# Altium schematic sheet Main.SchDoc
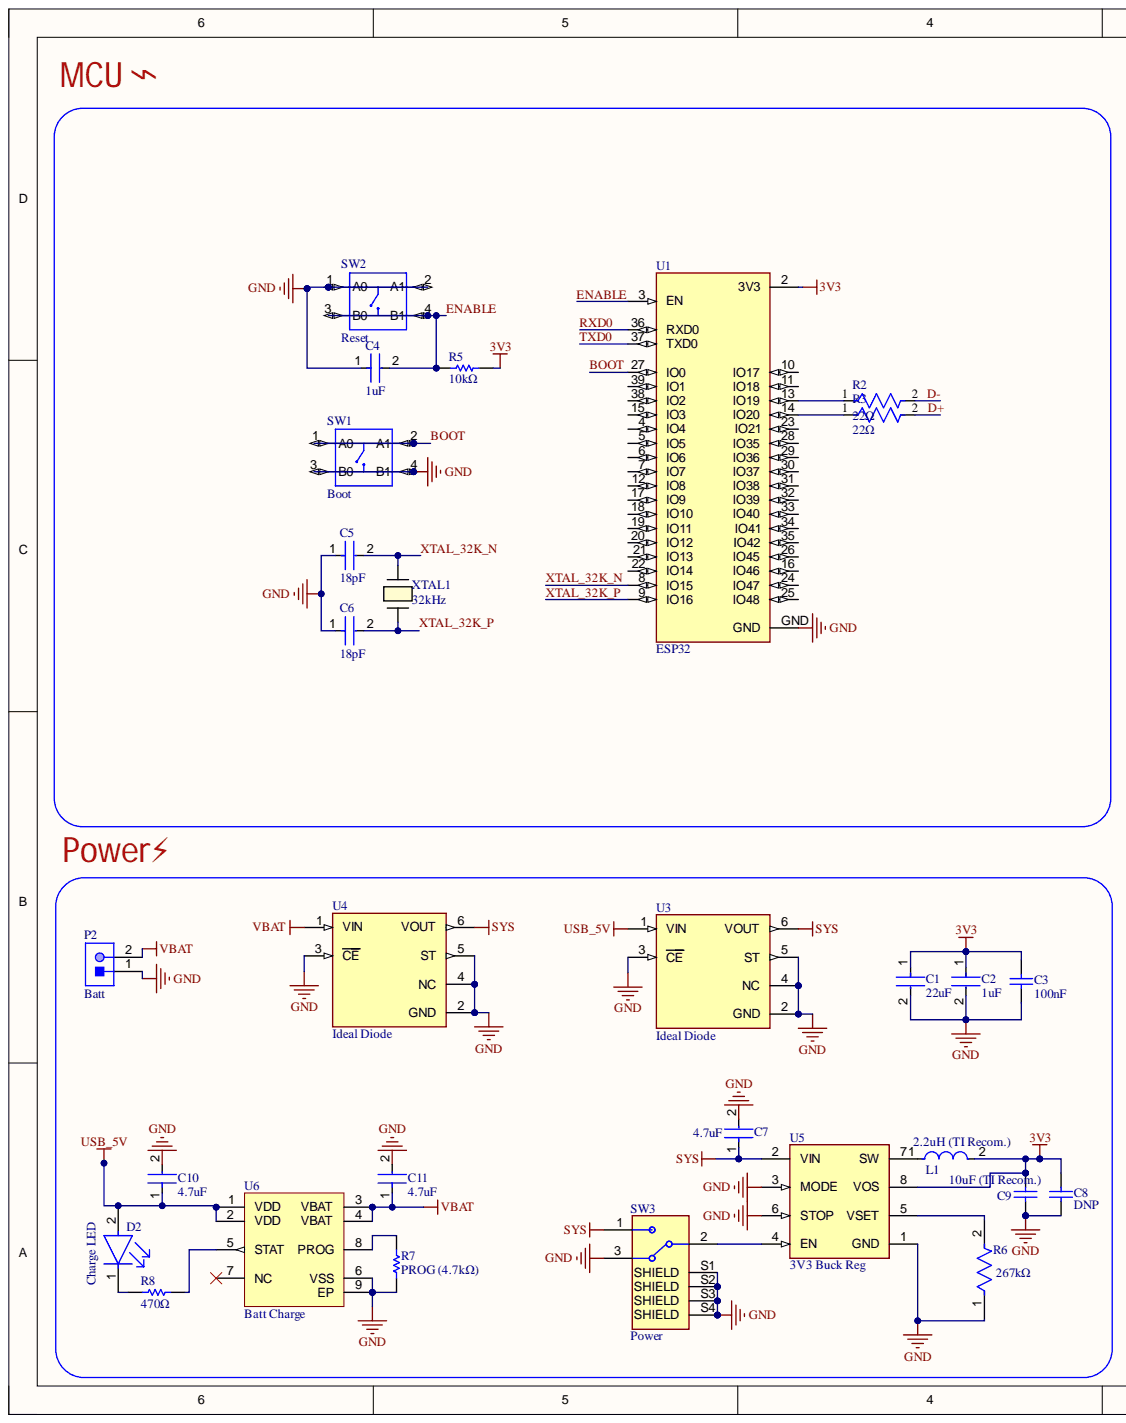
[diagram p0: Main.SchDoc, left]
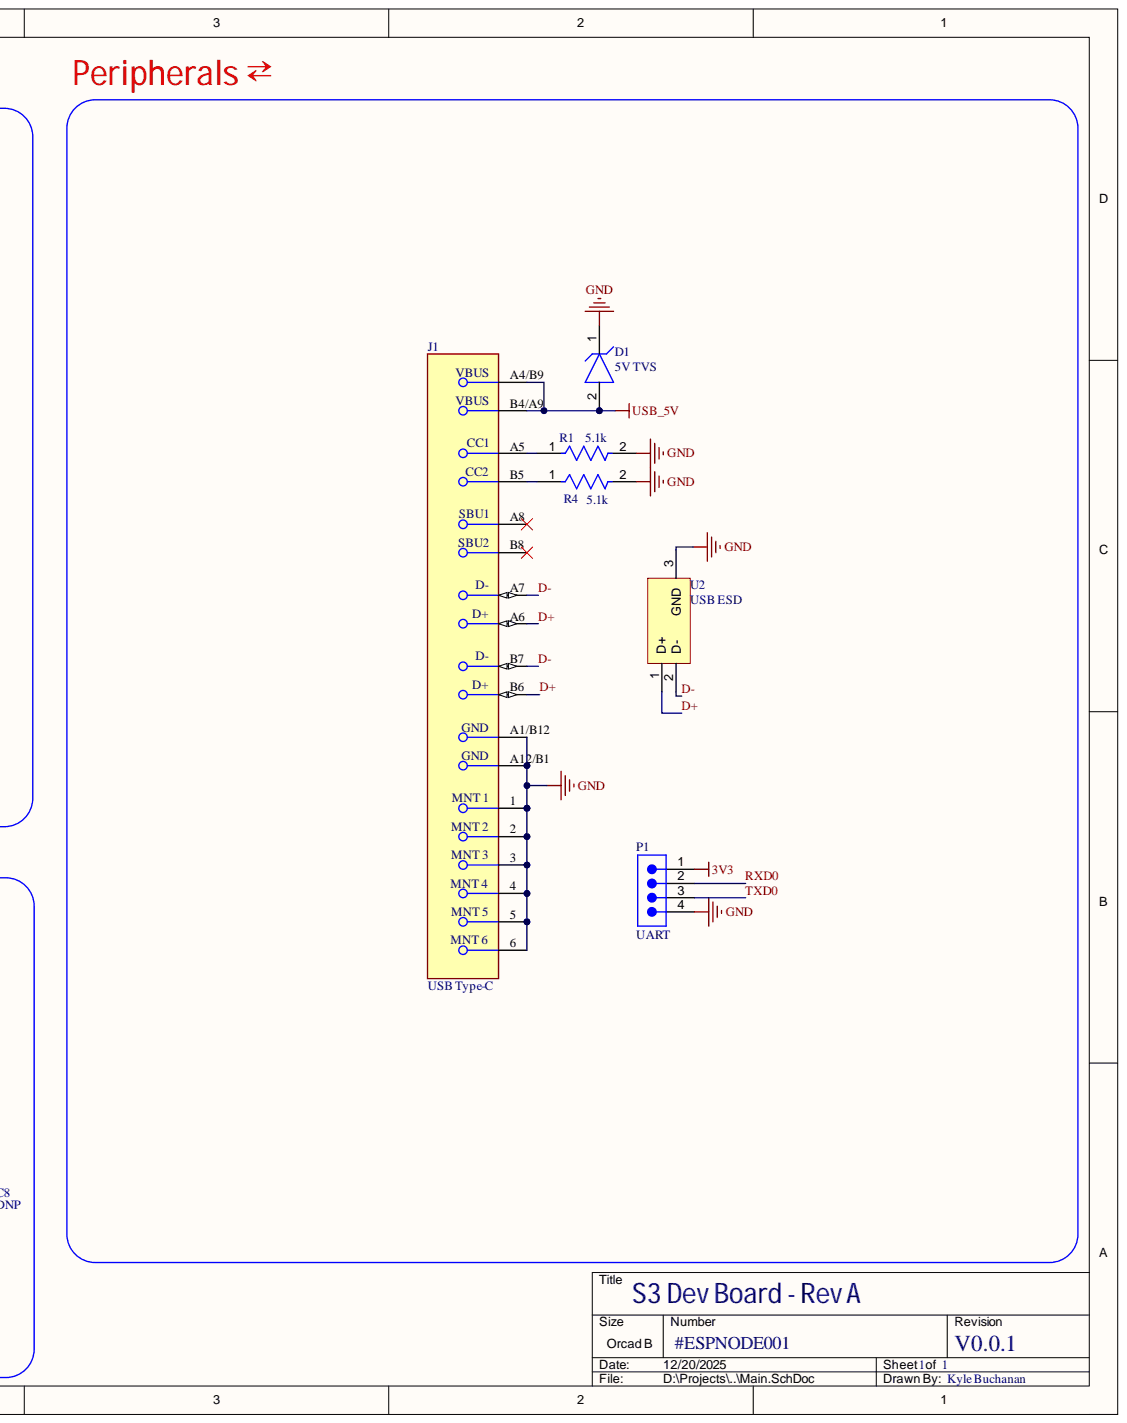
[diagram p1: Main.SchDoc, right]

## Parts
C1  22uF  [FP-CL21-IPC_A]
C2  1uF  [FP-CL10-IPC_A]
C3  100nF  [CAPC1050X55X23ML05T13]
C4  1uF  [FP-CL10-IPC_A]
C5  18pF  [FP-CL10-IPC_A]
C6  18pF  [FP-CL10-IPC_A]
C7  4.7uF  [FP-CL10-IPC_C]
C8  DNP  [CAPC1005X55X25NL05T10]  (no BOM)
C9  10uF (TI Recom.)  [CAPC1005X55X25NL05T10]
C10  4.7uF  [FP-CL10-IPC_C]
C11  4.7uF  [FP-CL10-IPC_C]
D1  5V TVS  Reverse Standoff Voltage: 5V  [FP-SMB-MFG]
D2  Charge LED  [FP-LTST-C170GKT-MFG]
J1  USB Type-C  [FP-DX07S016JA1R1500-MFG]
L1  2.2uH (TI Recom.)  [FP-DFE201612C-MFG]
P1  UART  [SMTC-TSW-104-08-X-S]  (no BOM)
P2  Batt  [JST-S2B-PH-K-S_V]
R1  5.1k  [FP-CRCW0603-e3-IPC_A]
R2  22Ω  [FP-RC0402-0_4-MFG]
R3  22Ω  [FP-RC0402-0_4-MFG]
R4  5.1k  [FP-CRCW0603-e3-IPC_A]
R5  10kΩ  [RESC1005X40X25LL05T10]
R6  267kΩ  [FP-RT0603-MFG]
R7  PROG (4.7kΩ)  [YAGO-RC0805_M]
R8  470Ω  [RESC1005X40X25ML05T10]
SW1  Boot  [SW4-SMD-5.2X5.2X1.5MM]
SW2  Reset  [SW4-SMD-5.2X5.2X1.5MM]
SW3  Power  [SW_PCM12SMTR]
U1  ESP32  [XCVR_ESP32-S3-WROOM-1-N16R2]
U2  USB ESD  [DRT0003A]
U3  Ideal Diode  [SOT65P210X110-6N]
U4  Ideal Diode  [SOT65P210X110-6N]
U5  3V3 Buck Reg  Switching Topology: Buck  [FP-DLC0008B-MFG]
U6  Batt Charge  [DFN-MC8]
XTAL1  32kHz  [XTAL_FC-135R_EPS]

## Nets
3V3: C1.1 C2.1 C3.2 C8.2 C9.2 L1.2 P1.1 R5.2 U1.2(3V3) U5.8(VOS)
GND: C1.2 C2.2 C3.1 C4.1 C5.1 C6.1 C7.2 C8.1 C9.1 C10.2 C11.2 D1.1 J1.1 J1.2 J1.3 J1.4 J1.5 J1.6 J1.A1/B12 J1.A12/B1 P1.4 P2.1 R1.2 R4.2 R6.1 R7.1 SW1.3(B0) SW1.4(B1) SW2.1(A0) SW2.2(A1) SW3.3 SW3.S1(SHIELD) SW3.S2(SHIELD) SW3.S3(SHIELD) SW3.S4(SHIELD) U1.GND U2.3 U3.2(GND) U3.3(CE) U3.4(NC) U3.5(ST) U4.2(GND) U4.3(CE) U4.4(NC) U4.5(ST) U5.1(GND) U5.3(MODE) U5.6(STOP) U6.6(VSS) U6.9(EP)
SYS: C7.1 SW3.1 U3.6(VOUT) U4.6(VOUT) U5.2(VIN)
USB_5V: C10.1 D1.2 D2.2 J1.A4/B9 J1.B4/A9 U3.1(VIN) U6.1(VDD) U6.2(VDD)
VBAT: C11.1 P2.2 U4.1(VIN) U6.3(VBAT) U6.4(VBAT)
BOOT: SW1.1(A0) SW1.2(A1) U1.27(IO0)
D+: J1.A6 J1.B6 R3.2 U2.1
D-: J1.A7 J1.B7 R2.2 U2.2
ENABLE: C4.2 R5.1 SW2.3(B0) SW2.4(B1) U1.3(EN)
RXD0: P1.2 U1.36(RXD0)
TXD0: P1.3 U1.37(TXD0)
XTAL_32K_N: C5.2 U1.8(IO15) XTAL1.2
XTAL_32K_P: C6.2 U1.9(IO16) XTAL1.1
NetD2_1: D2.1 R8.1
NetJ1_A5: J1.A5 R1.1
NetJ1_B5: J1.B5 R4.1
NetL1_1: L1.1 U5.7(SW)
NetR2_1: R2.1 U1.13(IO19)
NetR3_1: R3.1 U1.14(IO20)
NetR6_2: R6.2 U5.5(VSET)
NetR7_2: R7.2 U6.8(PROG)
NetR8_2: R8.2 U6.5(STAT)
NetSW3_2: SW3.2 U5.4(EN)

Not connected: J1 A8 B8; U1 4(IO4) 5(IO5) 6(IO6) 7(IO7) 10(IO17) 11(IO18) 12(IO8) 15(IO3) 16(IO46) 17(IO9) 18(IO10) 19(IO11) 20(IO12) 21(IO13) 22(IO14) 23(IO21) 24(IO47) 25(IO48) 26(IO45) 28(IO35) 29(IO36) 30(IO37) 31(IO38) 32(IO39) 33(IO40) 34(IO41) 35(IO42) 38(IO2) 39(IO1); U6 7(NC)

## Library data of the parts
C1 = CMP-13271-000185-1: CL21 Series 0805 22uF 6.3V ±20% Tolerance X5R Multilayer Ceramic Chip Capacitor; MPN: CL21A226MQQNNNE; Capacitance: 22uF; Case Code (Imperial): 0805; Case Code (Metric): 2012; Case/Package: 0805; Component Kind: Standard; Contact Plating: Tin; Depth: 1.25mm; Dielectric: X5R; Height: 1.25mm; LCSC Part #: C5674; Lead Free: Lead Free; Length: 2mm; Library Name: Altium Content Vault; Max Operating Temperature: 85°C; Min Operating Temperature: -55°C; Mount: Surface Mount; Package Quantity: 2000; Packaging: Tape and Reel; Radiation Hardening: No; Thickness: 0.055inch; Tolerance: 20%; Voltage Rating: 6.3V; Voltage Rating (DC): 6.3V; Width: 0.049inch
C2 C4 = CMP-13271-001457-1: Cap Ceramic 1uF 16V X5R ±10% Pad SMD 0603 +85°C T/R; MPN: CL10A105KO8NNNC; Capacitance: 1uF; Case Code (Imperial): 0603; Case Code (Metric): 1608; Case/Package: 0603; Component Kind: Standard; Contact Plating: Tin; Depth: 0.8mm; Dielectric: X5R; Height: 0.8mm; LCSC Part #: C1592; Lead Free: Lead Free; Length: 1.6mm; Library Name: Altium Content Vault; Material: Ceramic; Max Operating Temperature: 85°C; Min Operating Temperature: -55°C; Mount: Surface Mount; Package Quantity: 1; Packaging: Tape and Reel; Radiation Hardening: No; Thickness: 0.035inch; Tolerance: 10%; Voltage: 16V; Voltage Rating: 16V; Voltage Rating (DC): 16V; Width: 0.031inch
C3 = CMP-2000-05439-1: Chip Capacitor, 100 nF, +/- 10%, 16 V, -55 to 125 degC, 0402 (1005 Metric), RoHS, Tape and Reel; Manufacturer: Samsung Electro-Mechanics; MPN: CL05B104KO5NNNC; Capacitance: 100000pF; Dielectric: X7R; Rated Voltage: 16V; Case Size/Dimension: 0402; Component Kind: Standard; Datasheet URL: https://datasheet.ciiva.com/29198/458718-da-01-en-vs-kondensator-0402-29198423.pdf; Datasheet Version: Nov-10; LCSC Part #: C1525; Library Name: Altium Content Vault; Manufacturer URL: http://www.samsungsem.com/global/index.jsp; Tolerance (%): ±10%
C5 C6 = CMP-2000-06099-2: Cap Ceramic 18pF 50V C0G ±5% Pad SMD 0603 +125°C T/R; MPN: CL10C180JB8NNNC; Temperature Coefficient: 300ppm/°C; Capacitance: 18pF; Case Code (Imperial): 0603; Case Code (Metric): 1608; Case/Package: 0603; Component Kind: Standard; Contact Plating: Tin; Depth: 0.8mm; Dielectric: C0G; Height: 0.8mm; LCSC Part #: C1647; Length: 1.6mm; Library Name: Altium Content Vault; Material: Ceramic; Max Operating Temperature: 125°C; Min Operating Temperature: -55°C; Mount: Surface Mount; Packaging: Tape and Reel; Radiation Hardening: No; Thickness: 0.035inch; Tolerance: 5%; Voltage: 50V; Voltage Rating: 50V; Width: 0.031inch
C7 C10 C11 = CMP-13271-003017-1: Cap Ceramic 4.7uF 10V X5R ±10% Pad SMD 0603 +85°C T/R; MPN: CL10A475KP8NNNC; Capacitance: 4.7uF; Case Code (Imperial): 0603; Case Code (Metric): 1608; Case/Package: 0603; Component Kind: Standard; Contact Plating: Tin; Depth: 0.8mm; Dielectric: X5R; Height: 0.8mm; LCSC Part #: C1705; Length: 1.6mm; Library Name: Altium Content Vault; Max Operating Temperature: 85°C; Min Operating Temperature: -55°C; Mount: Surface Mount; Package Quantity: 1; Packaging: Tape and Reel; Radiation Hardening: No; Thickness: 0.035inch; Tolerance: 10%; Voltage Rating: 10V; Width: 0.031inch
C8 = CMP-2008-04012-1: Manufacturer: Murata; Part Number: GRM155R60G106ME44D; LCSC Part #: DNP; Library Name: Altium Content Vault; MPN: DNP
C9 = CMP-2008-04012-1: Manufacturer: Murata; MPN: GRM155R60G106ME44D; Component Kind: Standard; LCSC Part #: C162167; Library Name: Altium Content Vault; Part Number: GRM155R60G106ME44D
D1 = CMP-12828-000017-1: TVS DIODE 5V 9.2V SMB; Manufacturer: Diodes Inc; Manufacturer Part Number: SMBJ5.0A-13-F; Breakdown Voltage: 6.4V; Direction: Unidirectional; Max Junction Temp: +150°C; Number Of Channels: 1; Peak Pulse Current: 65.2A; Peak Pulse Power: 600W; Standoff Height: 0.05mm; Automotive: No; Category: Diode; Clamping Voltage: 9.2V; Component Kind: Standard; ComponentLink2Description: Datasheet; ComponentLink2URL: https://www.diodes.com/assets/Datasheets/ds19002.pdf; Device Class L1: Circuit Protection; Device Class L2: TVS Diodes; Device Class L3: unset; Digikey Description: TVS DIODE 5V 9.2V SMB; Footprint Url: https://www.diodes.com/assets/Package-Files/SMB.pdf; Height: 2.5mm; LCSC Part #: C110528; Lead Free: Yes; Library Name: Altium Content Vault; Mouser Description: TVS Diodes - Transient Voltage Suppressors 600W 5.0V; MPN: SMBJ5.0A-13-F; Octopart: https://octopart.com/smbj5.0a-13-f-diodes+inc.-350946; Package: SMB; RoHS: TRUE; Temperature: -55°C to +150°C; Temperature Range High: +150°C; Temperature Range Low: -55°C
D2 = CMP-1674-00002-6: LED GREEN CLEAR SMD; MPN: LTST-C170GKT; Dominant Wavelength: 569nm; Forward Current: 30mA; Forward Voltage: 2.1V; Lens Color: Colorless; Lens Style: Diffused; Luminous Intensity: 6mcd; Number of Elements: 1; Power Dissipation: 100mW; Reverse Voltage: 5V; Test Current: 10mA; Viewing Angle: 130°; Wavelength: 565nm; Case Code (Imperial): 0805; Case Code (Metric): 2012; Case/Package: 0805; Color: Green; Component Kind: Standard; Depth: 1.25mm; Height: 1.1mm; Illumination Color: Green; LCSC Part #: C125090; Lead Free: Lead Free; Length: 2mm; Lens Transparency: Clear; Library Name: Altium Content Vault; Max Operating Temperature: 85°C; Min Operating Temperature: -55°C; Mount: Surface Mount; Number of LEDs: 1; Number of Pins: 2; Package Quantity: 1; Packaging: Tape and Reel; Power Rating: 100mW; Radiation Hardening: No; Voltage: 5V; Width: 1.25mm
J1 = CMP-19038-000003-1: CONN RCP USB2.0 TYP C 24P SMD RA; MPN: DX07S016JA1R1500; Component Kind: Standard; LCSC Part #: C3197885; Library Name: Altium Content Vault
L1 = CMP-06042-009962-1: Shielded Wirewound Inductor 2.2uH 20% 1800mA 0.116Ω 0806 (2016); MPN: DFE201612E-2R2M=P2; Component Kind: Standard; LCSC Part #: C337893; Library Name: Altium Content Vault
P1 = CMP-1024-00357-1: 0.025" SQ Post Header, Through-hole, Vertical, -55 to 125 degC, 2.54 mm Pitch, 4-Pin, Male, RoHS; Manufacturer: Samtec; MPN: DNP; PartNumber: TSW-104-08-G-S; Pitch: 2.54 mm; ComponentLink1Description: Manufacturer URL; ComponentLink1URL: http://www.samtec.com; ComponentLink2Description: Datasheet; ComponentLink2URL: http://www.samtec.com/documents/webfiles/pdf/TSW_TH.PDF; ComponentLink3Description: Package Specification; ComponentLink3URL: http://www.samtec.com/documents/webfiles/cpdf/TSW-XXX-XX-XXX-X-XX-XXX-MKT.pdf; DatasheetVersion: F-214; LCSC Part #: DNP; Library Name: Altium Content Vault; Mounting Technology: Through-hole; Orientation: Vertical; PackageDescription: .025[0.64] SQ. Post Terminal Strip Assembly; PackageReference: TSW-104-08-X-S; PackageVersion: DM, 8/1988; RoHS: True; Temperature Range: -55 to 125 degC
P2 = CMP-1755-00004-1: Male Header, Pitch 2 mm, 1 x 2 Position, Height 4.8 mm, Tail Length 3.4 mm, -25 to 85 degC, RoHS, Bulk; MPN: S2B-PH-K-S(LF)(SN); Case\Package: Radial; Tolerance: 20%; Voltage Rating: 50 V; Component Kind: Standard; ComponentLink1Description: Datasheet; ComponentLink1URL: http://www.jst-mfg.com/product/pdf/eng/ePH.pdf; DatasheetVersion: Dec-12; Diameter: 5 mm; Height: 11 mm; LCSC Part #: C173752; Lead Pitch: 2 mm; Length: 11 mm; Library Name: Altium Content Vault; Max Operating Temperature: 85 degC; Min Operating Temperature: -40 degC; Mount: Through Hole; Package Quantity: 200; PackageDescription: Male Header, Pitch 2 mm, 1 x 2 Position, Height 4.8 mm, Tail Length 3.4 mm; PackageReference: S2B-PH-K-S; Packaging: Bulk; Pins: 2; Radiation Hardening: No; REACH SVHC: No SVHC; RoHSCompliant: true; Voltage Rating (DC): 50 V
R1 R4 = CMP-2000-03480-2: RES Thick Film, 5.1kΩ, 5%, 0.1W, 200ppm/°C, 0603; MPN: CRCW06035K10JNEA; Max Power Dissipation: 100mW; Resistance: 5.1kR; Voltage Rating: 75V; Case Code (Imperial): 0603; Case Code (Metric): 1608; Case/Package: 0603; Component Kind: Standard; Composition: Thick Film; Contact Plating: Tin; Depth: 0.85mm; Features: Automotive AEC-Q200; Height: 0.02inch; LCSC Part #: C14677; Lead Free: Lead Free; Length: 1.55mm; Library Name: Altium Content Vault; Max Operating Temperature: 155°C; Military Standard: Not; Min Operating Temperature: -55°C; Mount: Surface Mount; Number of Pins: 2; Number of Terminations: 2; Package Quantity: 1; Packaging: Tape and Reel; Power Rating: 100mW; Radiation Hardening: No; Temperature Coefficient: 200ppm/°C; Termination: SMD/SMT; Tolerance: 5%; Voltage Rating (DC): 75V; Weight: 7.1E-05oz; Width: 0.85mm
R2 R3 = CMP-2002-07520-2: Thick Film Resistors – SMD; YAGEO; RC0402FR-0722RL; 22 Ohm; 0402; 1 %; Power Rating: 63mW; Temperature Coefficient: 100ppm/°C; Tolerance: 1%; Voltage Rating: 50V; Case Code (Imperial): 0402; Case/Package: 0402; Component Kind: Standard; Composition: Thick Film; Depth: 500µm; Height: 400µm; LCSC Part #: C114765; Lead Free: Lead Free; Length: 1mm; Library Name: Altium Content Vault; Max Operating Temperature: 155°C; Military Standard: Not; Min Operating Temperature: -55°C; MPN: RC0402FR-0722RL; Number of Pins: 2; Packaging: Tape & Reel; REACH SVHC: Unknown; Resistance: 22Ω; RoHS: Compliant; Schedule B: 8533210045, 8533210045; Termination: SMD/SMT; Voltage Rating (AC): 50V; Voltage Rating (DC): 50V; Width: 500µm
R5 = CMP-2002-07353-1: Resistor, thick film, SMD 0402 (1005), 10 kΩ, 0.063 W, ±1 %; Manufacturer: Yageo / Phycomp; MPN: RC0402FR-0710KL; Component Kind: Standard; LCSC Part #: C60490; Library Name: Altium Content Vault; Part Number: RC0402FR-0710KL
R6 = CMP-03412-013520-1: RES SMD 267K OHM 0.1% 1/10W 0603; Manufacturer: Yageo; Manufacturer Part Number: RT0603BRE07267KL; Power Rating: 0.1W; Temperature Coefficient: 50 ppm/°C; Tolerance: 0.001; Voltage Rating: 75V; Automotive: No; Category: Res; Component Kind: Standard; ComponentLink2Description: Datasheet; ComponentLink2URL: http://www.yageo.com/documents/recent/PYu-RT_1-to-0.01_RoHS_L_9.pdf; Composition: Thin Film; Device Class L1: Passive Components; Device Class L2: Resistors; Device Class L3: Chip SMD Resistors; Digikey Description: RES SMD 267K OHM 0.1% 1/10W 0603; Footprint Url: http://www.yageo.com/exep/pages/download/literatures/PYu-R_Mount_10.pdf; Height: 0.55mm; Ipc Land Pattern Name: RESC16080X45; LCSC Part #: C861785; Lead Free: Yes; Library Name: Altium Content Vault; MPN: RT0603BRE07267KL; Octopart: https://octopart.com/rt0603bre07267kl-yageo-1458490; Package: RT0603; Resistance: 267KΩ; RoHS: TRUE; Series: RT; Temperature: -55°C to +155°C; Temperature Range High: +155°C; Temperature Range Low: -55°C; Value: 267KΩ
R7 = CMP-1568-00001-1: General Puprose Chip Resistor, 4700 Ohm +/- 1%, 0.125 W, -55 to 155 degC, 2-Pin SMD, RoHS, Tape and Reel; MPN: RC0805FR-074K7L; Power: 0.125 W; Tolerance: +/- 1%; Component Kind: Standard; ComponentLink1Description: Manufacturer URL; ComponentLink1URL: http://www.yageo.com/NewPortal/_en/index.jsp; ComponentLink2Description: Datasheet; ComponentLink2URL: http://www.yageo.com/documents/recent/PYu-RC_Group_51_RoHS_L-3.pdf; DatasheetVersion: Rev. 3; LCSC Part #: C60816; Library Name: Altium Content Vault; Mounting Technology: Surface Mount; PackageDescription: 2-Pin Surface Mount Device, Body 2 x 1.25 mm; Packing: Tape and Reel; PartNumber: RC0805FR-074K7L; Resistance: 4700 Ohm; RoHS: TRUE; Temperature Max: 155 degC; Temperature Min: -55 degC
R8 = CMP-2002-07697-1: Manufacturer: Yageo / Phycomp; MPN: RC0402FR-07470RL; Component Kind: Standard; LCSC Part #: C114877; Library Name: Altium Content Vault; Part Number: RC0402FR-07470RL
SW1 SW2 = SMD-BUTTON(4P-5.2X5.2X1.5MM-SKQGADE010): Switch Tactile N.O. SPST Round Button Gull Wing 0.05A 12VDC 2.55N SMD T/R; MF: ALPS; Check_prices: https://www.snapeda.com/parts/SKQGADE010/ALPS/view-part/?ref=eda; Component Kind: Standard; LCSC Part #: C116647; Library Name: SMD-BUTTON_4P-5.2X5.2X1.5MM-SKQGADE010_.IntLib; MP: SKQGADE010; MPN: SKQGADE010; Package: SMD-4 ALPS; SnapEDA_Link: https://www.snapeda.com/parts/SKQGADE010/ALPS/view-part/?ref=snap
SW3 = PCM12SMTR: Slide Switch SPDT Surface Mount, Right Angle; MF: C&K; Availability: In Stock; Check_prices: https://www.snapeda.com/parts/PCM12SMTR/C%2526K/view-part/?ref=eda; Component Kind: Standard; LCSC Part #: C221841; Library Name: PCM12SMTR.IntLib; MP: PCM12SMTR; MPN: PCM12SMTR; Package: None; Price: None; Purchase-URL: https://pricing.snapeda.com/search/part/PCM12SMTR/?ref=eda; SnapEDA_Link: https://www.snapeda.com/parts/PCM12SMTR/C%2526K/view-part/?ref=snap
U1 = ESP32-S3-WROOM-1-N16R2: Bluetooth, WiFi 802.11b/g/n, Bluetooth v5.0 Transceiver Module 2.4GHz ESP32-S3-WROOM-1-N16R2; MF: Espressif Systems; MP: ESP32S3WROOM1N16R2; Check_prices: https://www.snapeda.com/parts/ESP32S3WROOM1N16R2/Espressif+Systems/view-part/?ref=eda; Component Kind: Standard; LCSC Part #: C2913205; Library Name: ESP32-S3-WROOM-1-N16R2.IntLib; MPN: ESP32-S3-WROOM-1-N16R2; Package: NON STANDARD Espressif Systems; SnapEDA_Link: https://www.snapeda.com/parts/ESP32S3WROOM1N16R2/Espressif+Systems/view-part/?ref=snap
U2 = CMP-0326-00046-3: ESD Solution for Super-Speed (6 Gbps) USB 3.0 Interface, 2 Channels, -40 to +85 degC, 3-pin SOT (DRT), Green (Rohs & No Sb/Br); Manufacturer: Texas Instruments; MPN: TPD2EUSB30DRTR; 1394 / Firewire: TRUE; Breakdown Voltage(Min)(V): 9; Differential Capacitance(pF): 0.01; IEC 61000-4-2 Air-Gap(+/- kV): 8; IO Capacitance(Typ)(pF): 0.7; IO Leakage Current(nA): 10; Component Kind: Standard; ComponentLink1Description: Datasheet; ComponentLink1URL: http://www.ti.com/general/docs/lit/getliterature.tsp?genericPartNumber=TPD2EUSB30&fileType=pdf; ComponentLink2Description: URL Link1; ComponentLink2URL: SamplesURL:http://www.ti.com/product/tpd2eusb30#samples; DatasheetVersion: SLVSAC2C; Eco-Plan: Green (RoHS & no Sb/Br); HDMI: TRUE; IEC 61000-4-2 Contact(+/- kV): 8; LCSC Part #: C97502; Library Name: Altium Content Vault; Mounting Technology: Surface Mount; PackageDescription: 3-Flat Lead Plastic Small Outline, 1 x 1 mm (inc leads), 0.35 mm Pitch; PackageReference: DRT0003A; PackageVersion: revD, Jun-2008; PartNumber: TPD2EUSB30DRTR; Serial ATA / eSATA: TRUE; SubFamily: ESD Solution; USB Full Speed: TRUE; USB High Speed: TRUE; USB Super Speed: TRUE
U3 U4 = LM66100DCKR: 1.5-V to 5.5-V, 1.5-A, 0.5-ÂµA IQ ideal diode with integrated FET; MF: Texas Instruments; Check_prices: https://www.snapeda.com/parts/LM66100DCKR/Texas+Instruments/view-part/?ref=eda; Component Kind: Standard; LCSC Part #: C2869734; Library Name: LM66100DCKR.IntLib; MP: LM66100DCKR; MPN: LM66100DCKR; Package: SOT-SC70-6 Texas Instruments; SnapEDA_Link: https://www.snapeda.com/parts/LM66100DCKR/Texas+Instruments/view-part/?ref=snap
U5 = CMP-04918-000086-1: ULTRA LOW IQ BUCK CONVERTER; Manufacturer: Texas Instruments; Manufacturer Part Number: TPS62840DLCR; Max Duty Cycle: 100%; Max Junction Temp: +125°C; Max Supply Voltage: 6.5V; Min Supply Voltage: 1.8V; Nominal Supply Current: 0.005-3000uA; Number Of Outputs: 1; Output Current: 750mA; Output Type: AdjustableProgrammable; Output Voltage: 1.8-3.3V; Standoff Height: 0mm; Switching Frequency: 1.8MHz; Automotive: No; Category: IC; Component Kind: Standard; ComponentLink2Description: Datasheet; ComponentLink2URL: http://www.ti.com/lit/ds/symlink/tps62840.pdf; Device Class L1: Integrated Circuits (ICs); Device Class L2: Power Management ICs; Device Class L3: Voltage Regulators - Switching; Digikey Description: ULTRA LOW IQ BUCK CONVERTER; Height: 1mm; Ipc Land Pattern Name: DFN200X150X100-8; LCSC Part #: C2071859; Lead Free: Yes; Library Name: Altium Content Vault; Mouser Description: 60-nA IQ, 1.8-V to 6.5-VIN, high-efficiency 750-mA step-down converter 8-VSON-HR -40 to 125; MPN: TPS62840DLCR; Octopart: https://octopart.com/tps62840dlcr-texas+instruments-103121111; Package: DLC0008B; RoHS: TRUE; Temperature: -40°C to +125°C; Temperature Range High: +125°C; Temperature Range Low: -40°C
U6 = CMP-0133-00082-1: Miniature Single-Cell, Fully Integrated Li-Ion, Li-Polymer Charge Management Controller, 4.2V, 8-Pin DFN, Industrial Temperature, Tape and Reel; MPN: MCP73831T-2DCI/MC; Component Kind: Standard; DatasheetVersion: revE, Sep-2008; LCSC Part #: C623847; Library Name: Altium Content Vault; PackageDescription: 8-Lead Plastic Dual Flat, No Lead Package (MC) - 2x3x0.9mm Body [DFN]; PackageReference: DFN-MC8; PackageVersion: revBB, Aug-2009
XTAL1 = FC-135 32.7680KA-A3: SMD Crystal 32.768KHz-12.5pF-20ppm-2P-3.2*1.5*0.9mm; Manufacturer_Name: Epson; Component Kind: Standard; LCSC Part #: C32346; Library Name: FC-13532P7680KA-A3.IntLib; Manufacturer_Part_Number: FC-135 32.7680KA-A3; MPN: FC-135 32.7680KA-A3; Copyright: Copyright (C) 2025 Ultra Librarian. All rights reserved.
